# Altium schematic sheet LCD_Sheet.SchDoc
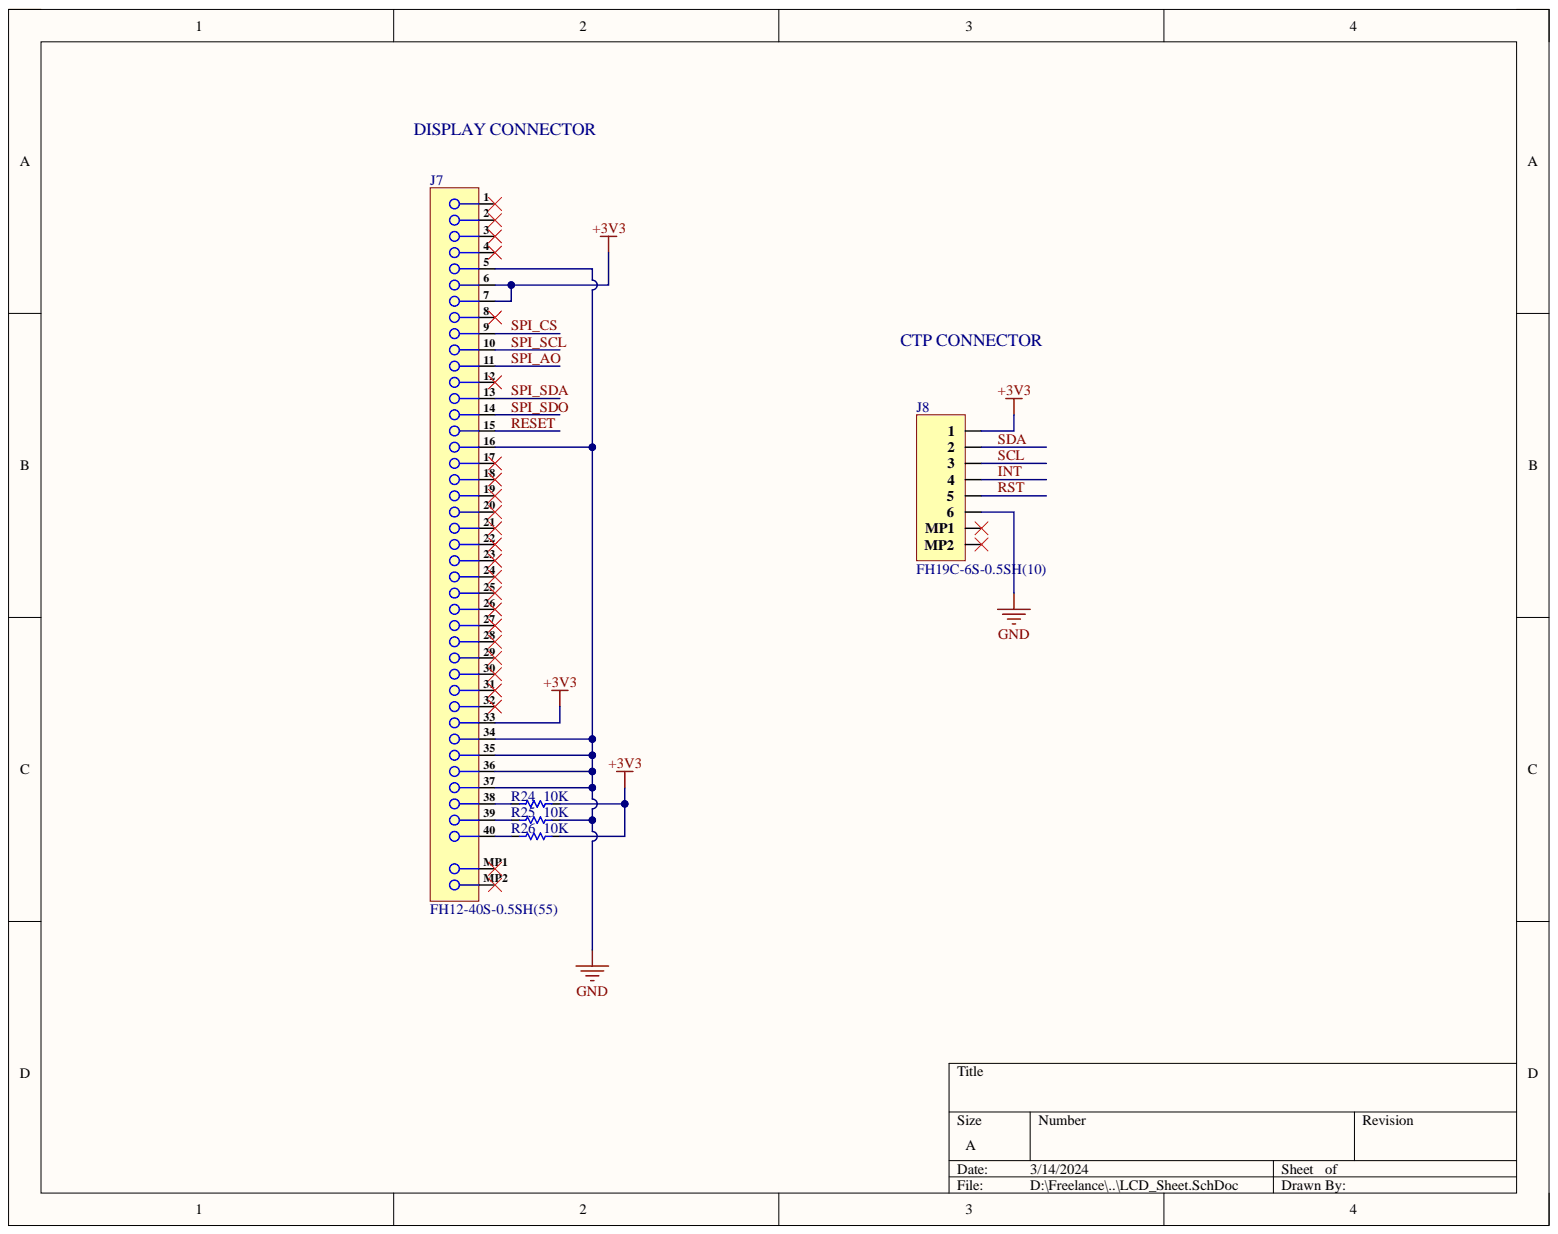
[diagram p0: LCD_Sheet.SchDoc]

## Parts
J7  FH12-40S-0.5SH(55)  [FH12-40S-0.5SH(55)]
J8  FH19C-6S-0.5SH(10)  [FH19C-6S-0.5SH(10)_]
R24  10K  [Res-0603]
R25  10K  [Res-0603]
R26  10K  [Res-0603]

## Nets
+3V3: J7.6 J7.7 J7.33 J8.1 R24.2 R26.2
GND: J7.5 J7.16 J7.34 J7.35 J7.36 J7.37 J8.6 R25.2
INT: J8.4
RESET: J7.15
RST: J8.5
SCL: J8.3
SDA: J8.2
SPI_AO: J7.11
SPI_CS: J7.9
SPI_SCL: J7.10
SPI_SDA: J7.13
SPI_SDO: J7.14
NetJ7_38: J7.38 R24.1
NetJ7_39: J7.39 R25.1
NetJ7_40: J7.40 R26.1

Not connected: J7 1 2 3 4 8 12 17 18 19 20 21 22 23 24 25 26 27 28 29 30 31 32 MP1 MP2; J8 MP1 MP2

## Library data of the parts
J7 = FH12-40S-0.5SH(55): CONN FFC BOTTOM 40POS 0.50MM R/A; Manufacturer: Hirose; Body Breadth: 0.079inch; Body Depth: 0.22inch; Body Length: 0.949inch; Connector Type: CARD EDGE CONNECTOR; Contact Pattern: RECTANGULAR; Contact Resistance: 50mR; Contact Style: BELLOWED TYPE; Dielectric Withstanding Voltage (AC): 150V; DIN Conformance: No; Durability: 20Cycles; Insulation Resistance: 500MR; Item Type: Connector; Mating Contact Pitch: 0.02inch; Mounting Option 1: EJECT LEVER; Mounting Option 2: LOCKING; Number of Contacts: 40; Number Of PCB Rows: 1; Option: General Purpose; Rated Current (Signal): 400mA; Reference Standard: UL; Reliability: COMMERCIAL; Category: FFC / FPC; Component Kind: Standard; ComponentLink1Description: https://datasheet.ciiva.com:443/pdfs/VipMasterIC/IC/HIRO/HIRO-S-A0003089604/HIRO-S-A0008599618-1.pdf?src-supplier=IHS+Markit; ComponentLink1URL: https://datasheet.ciiva.com:443/pdfs/VipMasterIC/IC/HIRO/HIRO-S-A0003089604/HIRO-S-A0008599618-1.pdf?src-supplier=IHS+Markit; ComponentLink2Description: https://datasheet.ciiva.com/11745/0900766b80d985fc-11745617.pdf?src-supplier=RSComponents; ComponentLink2URL: https://datasheet.ciiva.com/11745/0900766b80d985fc-11745617.pdf?src-supplier=RSComponents; ComponentLink3Description: https://datasheet.ciiva.com/15176/getdatasheetpartid-432539-15176843.pdf?src-supplier=Verical; ComponentLink3URL: https://datasheet.ciiva.com/15176/getdatasheetpartid-432539-15176843.pdf?src-supplier=Verical; ComponentLink4Description: https://datasheet.ciiva.com/7661/58803-7661389.pdf?src-supplier=Element14; ComponentLink4URL: https://datasheet.ciiva.com/7661/58803-7661389.pdf?src-supplier=Element14; Contact Finish - Mating: NOT SPECIFIED; Contact Finish - Termination: Nickel/Gold (Ni/Au); Contact Gender: Female; Filter Feature: No; Flammability Rating: UL94 V-0; IEC Conformance: No; Insulation Color: Beige; Insulation Material: Polyamide; JESD-609 Code: e4; LCSC Part Number: C202114; Library Name: eSpark Libraries.IntLib; Manufacturer Part Number: FH12-40S-0.5SH(55); Max Operating Temperature: 70°C; MIL Conformance: No; Min Operating Temperature: -40°C; Mixed Contacts: No; Mounting Style: RIGHT ANGLE; Mounting Technology: Board; Number of Rows Loaded: 1; PCB Contact Pattern: RECTANGULAR; Pricing 1: 1=3.00000, 10=2.72800, 25=2.58400, 50=2.52400, 100=2.40380, 250=2.10332, 500=2.04324, 1000=1.74276 (USD); Reach SVHC Compliant: Yes; RoHS: Yes; RoHS Compliant: Yes; Stock 1: 11247; Supplier 1: Digi-Key; Supplier Part Number 1: 26-FH12-40S-0.5SH(55)CT-ND; Terminal Pitch: 0.5mm; Termination: SMD/SMT
J8 = FH19C-6S-0.5SH(10): CONN FFC BOTTOM 6POS 0.50MM R/A; Manufacturer: Hirose; Item Type: FFC Connector; Category: FFC / FPC; Component Kind: Standard; ComponentLink1Description: https://datasheet.ciiva.com/18563/ed-fh19cfh19sc-20140305-18563530.pdf?src-supplier=Digi-Key; ComponentLink1URL: https://datasheet.ciiva.com/18563/ed-fh19cfh19sc-20140305-18563530.pdf?src-supplier=Digi-Key; LCSC Part number: C424651; Library Name: eSpark Libraries.IntLib; Manufacturer Part Number: FH19C-6S-0.5SH(10); Pricing 1: 1=1.10000, 10=0.96900, 25=0.91200, 50=0.87400, 100=0.83600, 250=0.76000, 500=0.68400, 1000=0.60800, 2500=0.55100 (USD); RoHS: Yes; Stock 1: 4697; Supplier 1: Digi-Key; Supplier Part Number 1: H125824CT-ND
R24-R26 = RT0603BRD0710KL: RES SMD 10K OHM 0.1% 1/10W 0603; Manufacturer: Yageo; Item Type: Resistor; Package Height: 0.45mm; Package Length: 1.6mm; Package Width: 0.8mm; Power: 100mW; Rated Temperature: 70°C; Temperature Coefficient: 25ppm/°C; Value: 10kR; Working Voltage: 75V; Case/Package: 0603; Category: Chip SMD Resistors; Component Kind: Standard; ComponentLink1Description: https://datasheet.ciiva.com:443/pdfs/VipMasterIC/IC/YAGO/YAGO-S-A0008510989/YAGO-S-A0008510989-1.pdf?src-supplier=IHS+Markit; ComponentLink1URL: https://datasheet.ciiva.com:443/pdfs/VipMasterIC/IC/YAGO/YAGO-S-A0008510989/YAGO-S-A0008510989-1.pdf?src-supplier=IHS+Markit; ComponentLink2Description: https://datasheet.ciiva.com/5624/pyu-rt-1-to-0-05-rohs-l-4-5624351.pdf?src-supplier=Mouser; ComponentLink2URL: https://datasheet.ciiva.com/5624/pyu-rt-1-to-0-05-rohs-l-4-5624351.pdf?src-supplier=Mouser; ComponentLink3Description: https://datasheet.ciiva.com/29721/pyu-rt-1-to-0-05-rohs-l-4-29721177.pdf?src-supplier=Digi-Key; ComponentLink3URL: https://datasheet.ciiva.com/29721/pyu-rt-1-to-0-05-rohs-l-4-29721177.pdf?src-supplier=Digi-Key; Construction: Chip; LCSC Part Number: C95204; Manufacturer Part Number: RT0603BRD0710KL; Max Operating Temperature: 155°C; Min Operating Temperature: -55°C; Mounting Technology: Surface Mount; Package Style: SMTMeter; Packaging: Tape and Reel; Pins: 2; Pricing 1: 100=0.29800, 250=0.27800, 500=0.25900, 1000=0.23700 (GBP); Reach SVHC Compliant: Yes; Resistor Type: FIXED RESISTOR; RoHS: Yes; RoHS Compliant: Yes; Stock 1: 20510; Supplier 1: Rs; Supplier Part Number 1: 1991391P; Technology: THIN FILM; Termination: Wraparound; Tolerance: 0.1%; Library Name: DigitalAzanClock.IntLib
